# Altium schematic sheet ARGB.SchDoc
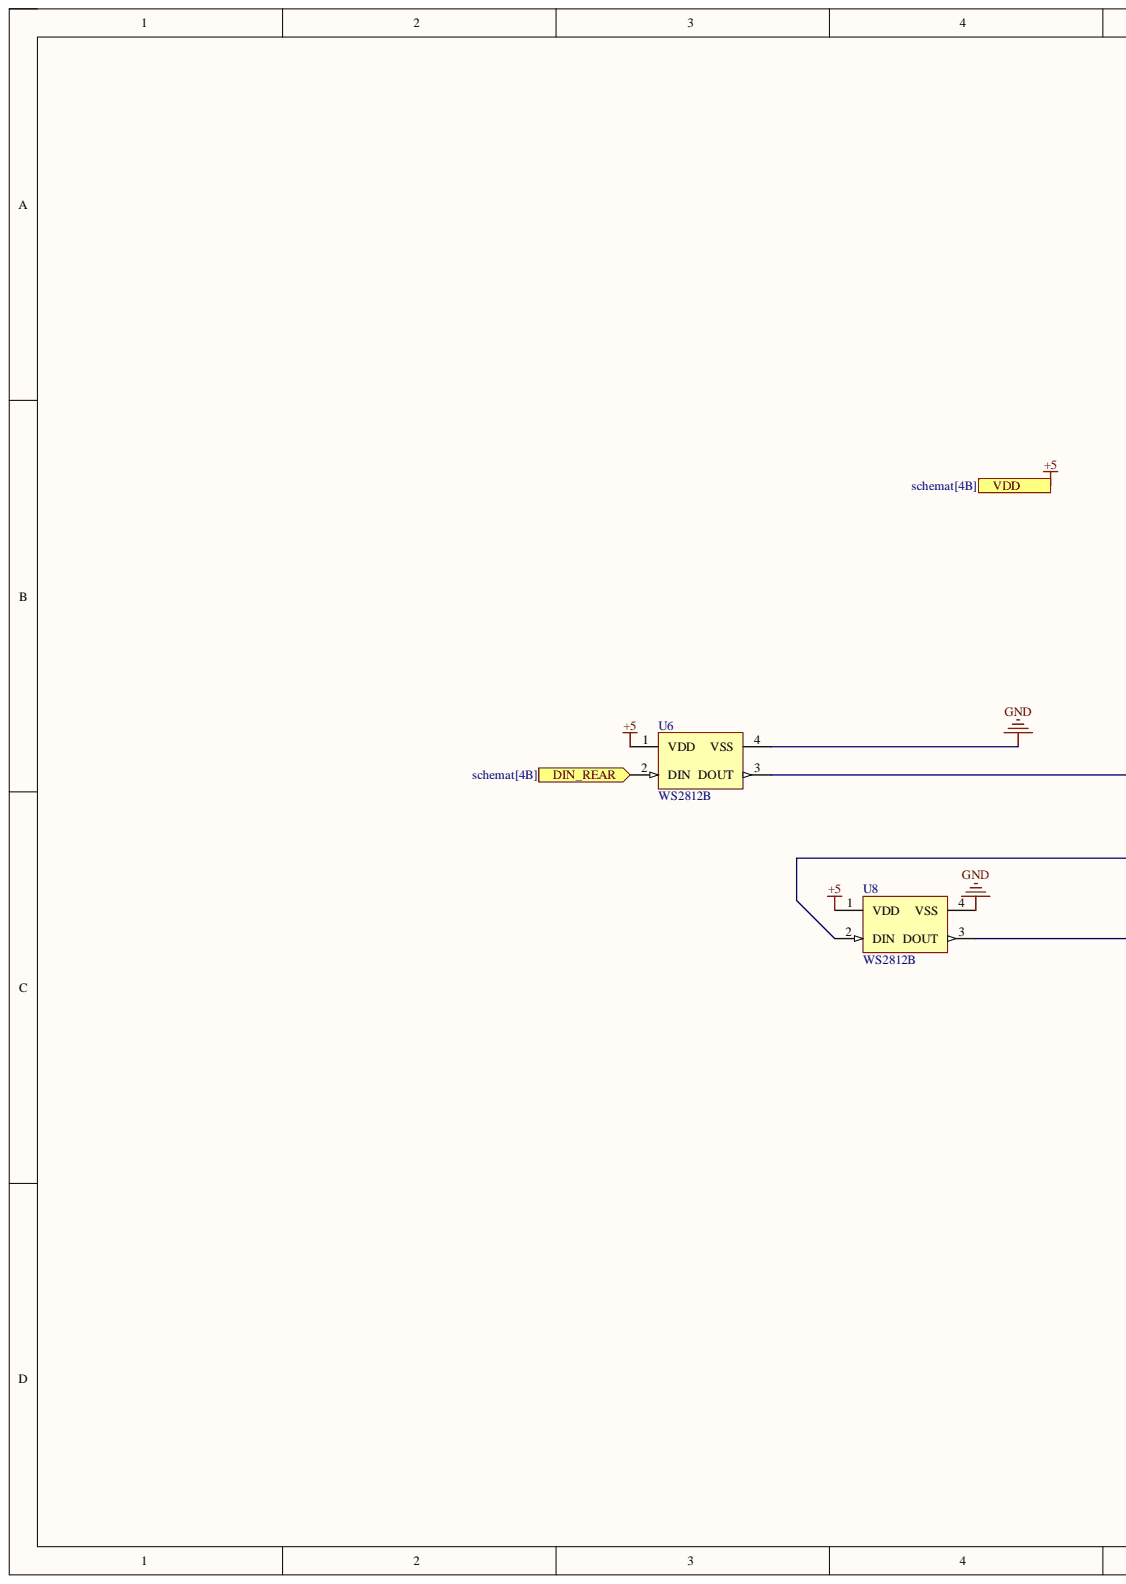
[diagram p0: ARGB.SchDoc, left]
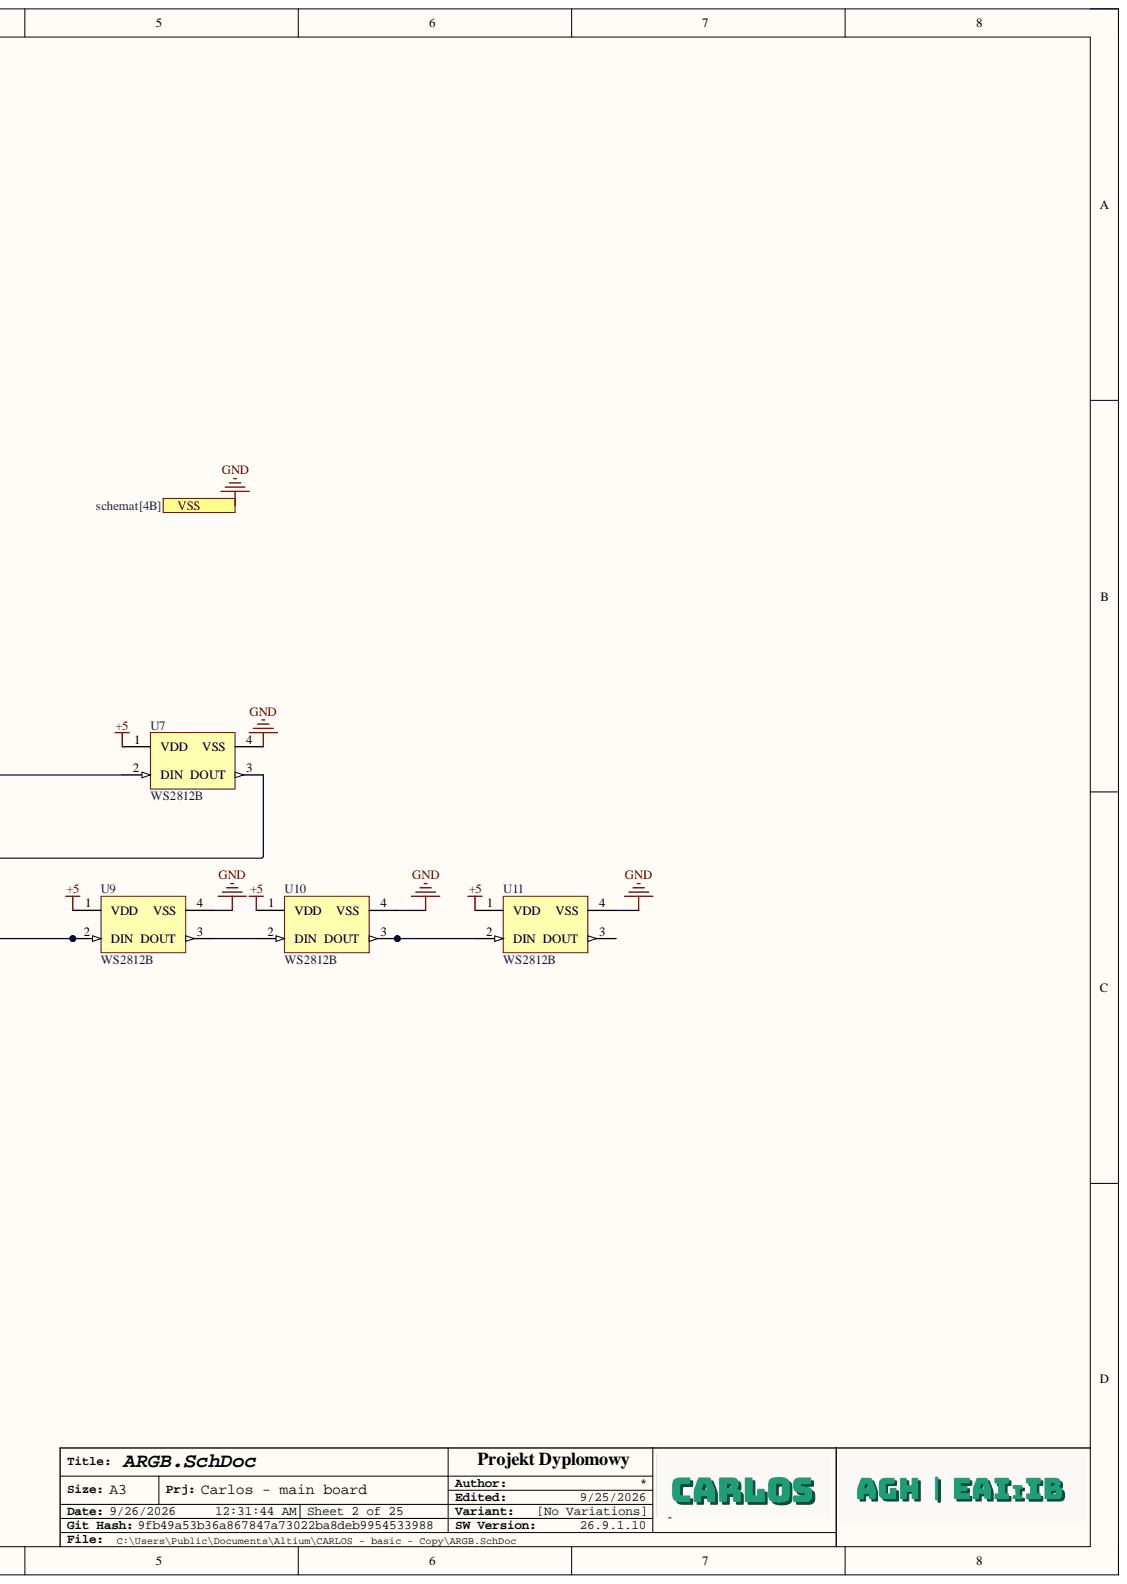
[diagram p1: ARGB.SchDoc, right]

## Parts
U6  WS2812B  [WS2812B 3030]
U7  WS2812B  [WS2812B 3030]
U8  WS2812B  [WS2812B 3030]
U9  WS2812B  [WS2812B 3030]
U10  WS2812B  [WS2812B 3030]
U11  WS2812B  [WS2812B 3030]

## Ports
DIN_REAR, VDD, VSS

## Nets
+5: U6.1(VDD) U7.1(VDD) U8.1(VDD) U9.1(VDD) U10.1(VDD) U11.1(VDD) ; port VDD
DIN_REAR: U6.2(DIN) ; port DIN_REAR
GND: U6.4(VSS) U7.4(VSS) U8.4(VSS) U9.4(VSS) U10.4(VSS) U11.4(VSS) ; port VSS
NetU6_3: U6.3(DOUT) U7.2(DIN)
NetU7_3: U7.3(DOUT) U8.2(DIN)
NetU8_3: U8.3(DOUT) U9.2(DIN)
NetU9_3: U9.3(DOUT) U10.2(DIN)
NetU10_3: U10.3(DOUT) U11.2(DIN)

Not connected: U11 3(DOUT)

## Library data of the parts
U6-U11 = CMP-007-00035-3: Intelligent Control LED Integrated Light Source, 3.5 to 5.3 V, -25 to 80 degC, 4-Pin SMD, RoHS, Bulk; Manufacturer: Adafruit Industries; Case/Package: WS2812B; Component Kind: Standard; Datasheet URL: https://cdn-shop.adafruit.com/datasheets/WS2812B.pdf; Datasheet Version: May-13; Manufacturer URL: https://www.adafruit.com/; Mounting Technology: SM; Package Description: 4-Pin Surface Mount Device, Body 5 x 5 mm, Pitch 3.3 mm; Package Reference: WS2812B; RoHS Compliant: False; Library Name: Jakub Sikora
